# Altium schematic sheet peripherials.SchDoc
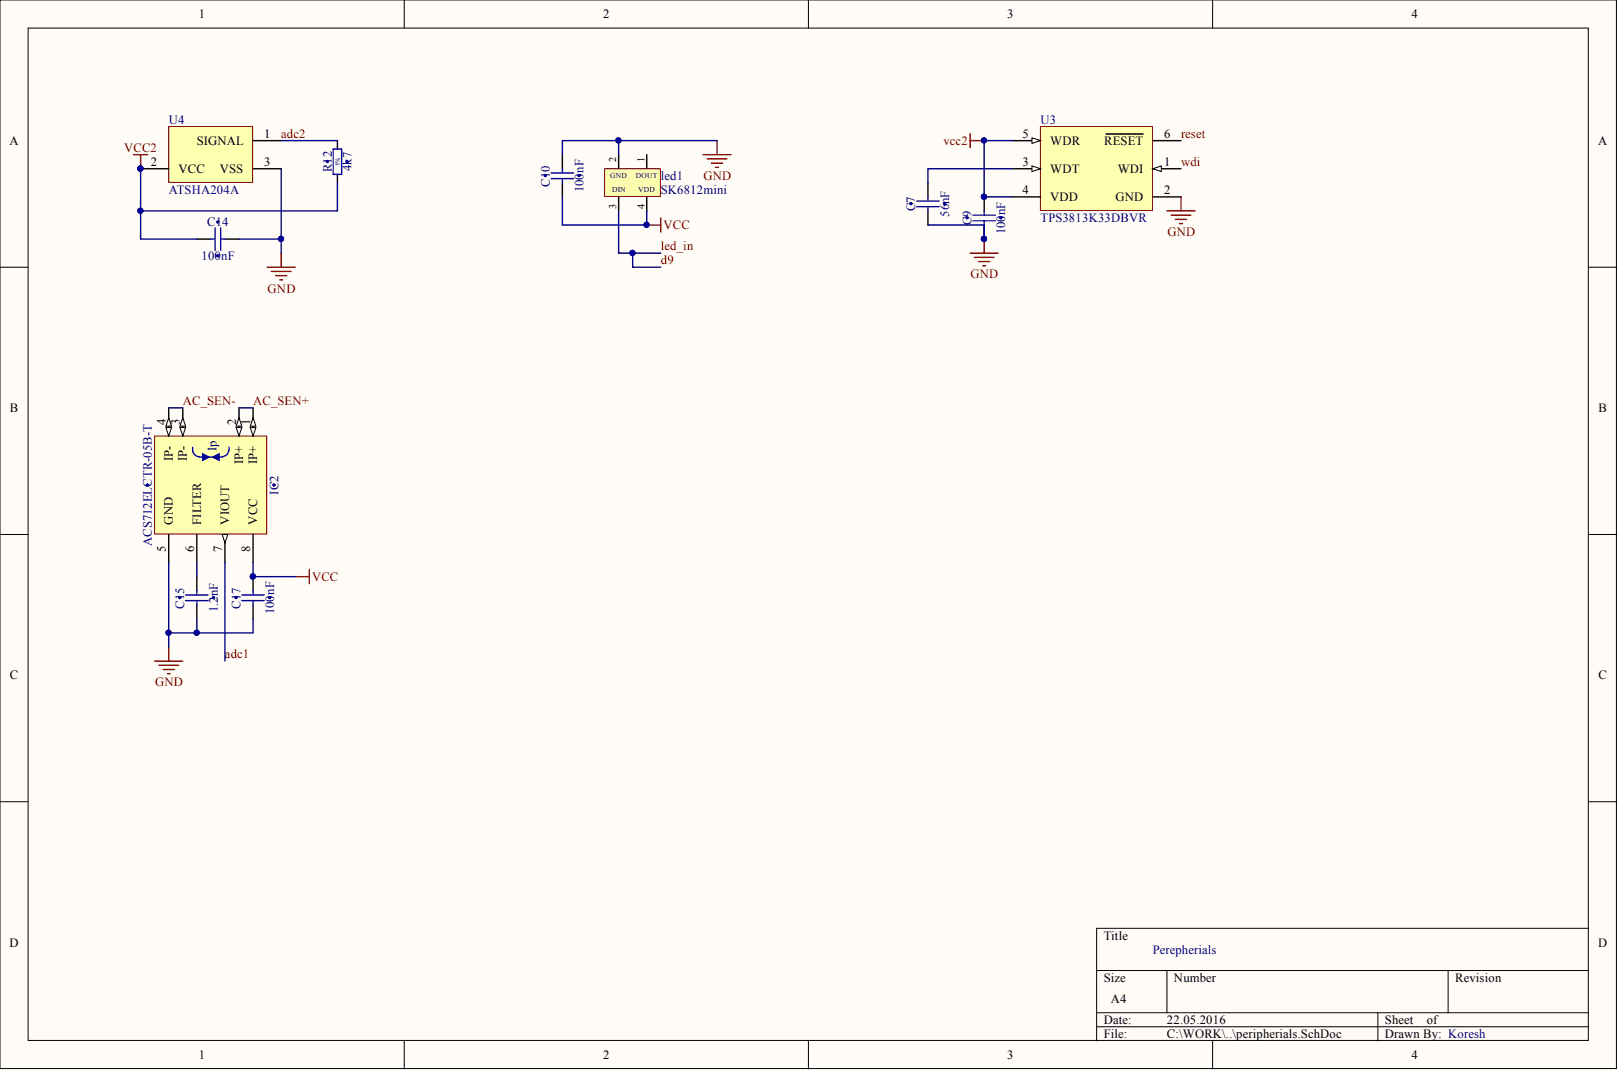
[diagram p0: peripherials.SchDoc]

## Parts
C7  56nF  [CAPC1005X55N]
C9  100nF  [CAPC1005X55N]
C10  100nF  [CAPC1005X55N]
C14  100nF  [CAPC1005X55N]
C15  1.2nF  [CAPC1608X85N]
C17  100nF  [CAPC1608X90N]
IC2  ACS712ELCTR-05B-T  [SOIC127P600X175-8N]
led1  SK6812mini  [SK6812mini]
R12  4k7  [RESC1005X40N]
U3  TPS3813K33DBVR  [DBV6_L]
U4  ATSHA204A  [3TS1_N]

## Nets
GND: C7.1 C9.1 C10.2 C14.2 C15.1 C17.1 IC2.5(GND) led1.2 U3.2(GND) U4.3
VCC: C10.1 C17.2 IC2.8(VCC) led1.4
VCC2: C9.2 C14.1 R12.1 U3.4(VDD) U3.5(WDR) U4.2
AC_SEN+: IC2.1(IP+) IC2.2(IP+)
AC_SEN-: IC2.3(IP-) IC2.4(IP-)
ADC1: IC2.7(VIOUT)
ADC2: R12.2 U4.1
D9: led1.3
RESET: U3.6(RESET)
WDI: U3.1(WDI)
NetC7_2: C7.2 U3.3(WDT)
NetC15_2: C15.2 IC2.6(FILTER)

Not connected: led1 1

## Library data of the parts
C7 = CC0402_68NF_16V_10%_X7R: Non-polarised capacitor; Manufacturer: GENERIC; Manufacturer1 Part Number: 223878715647; Part Description: SMD Multilayer Chip Ceramic Capacitor; Part Number: CC0402_56NF_16V_10%_X7R; Database Table Name: Capacitors SMD; TC: X7R; Tolerance: ±10%; Voltage: 16V; Case: 0402; Component Kind: Standard; Component Type: Standard; CreateDate: 03.12.2007; HelpURL: \\cern.ch\dfs\Applications\Altium\Datasheets\CC0402_X7R_PHYCOMP.pdf; LatestRevisionDate: 03.12.2007; Library Name: Capacitors.DbLib; Manufacturer1 ComponentHeight: 0.55mm; Manufacturer1 Example: PHYCOMP; Manufacturer Part Number: CC0402_68NF_16V_10%_X7R; Mounted: Yes; Pin Count: 2; SMD: Yes; Socket: No; Status: Not Recommended; Table: Capacitors SMD; Value: 56nF; Author: CERN DEM JLC
C9 C10 C14 = CC0402_100NF_16V_10%_X5R: Non-polarised capacitor; Manufacturer: GENERIC; Manufacturer1 Part Number: GRM155R61C104KA88D; Part Description: SMD Multilayer Chip Ceramic Capacitor; Database Table Name: Capacitors SMD; TC: X5R; Tolerance: ±10%; Voltage: 16V; Case: 0402; Component Kind: Standard; Component Type: Standard; CreateDate: 10.12.2013; HelpURL: \\cern.ch\dfs\Applications\Altium\Datasheets\CC0402_X5R_MURATA_GRM.pdf; LatestRevisionDate: 10.12.2013; Library Name: Capacitors.DbLib; Manufacturer1 ComponentHeight: 0.55mm; Manufacturer1 Example: MURATA; Manufacturer Part Number: CC0402_100NF_16V_10%_X5R; Mounted: Yes; Part Number: CC0402_100NF_16V_10%_X5R; Pin Count: 2; SMD: Yes; Socket: No; Status: None; Table: Capacitors SMD; Value: 100nF; Author: CERN DEM JLC
C15 = CPPS0603_1.2NF_16V_5%_PANASONIC_ECHU(X): Non-polarised capacitor; Manufacturer Part Number: ECHU1C122JX5; Part Description: Stacked Metallized PPS (Polyphenylene Sulfide) Film Chip Capacitor; Database Table Name: Capacitors SMD; Tolerance: ±5%; Voltage: 16V; Case: 0603; ComponentHeight: 0.85mm; Component Kind: Standard; Component Type: Standard; CreateDate: 15.06.2011; HelpURL: \\cern.ch\dfs\Applications\Altium\Datasheets\CPPS0603_PANASONIC_ECHU(X).pdf; LatestRevisionDate: 15.06.2011; Library Name: Capacitors.DbLib; Manufacturer: PANASONIC; Mounted: Yes; Part Number: CPPS0603_1.2NF_16V_5%_PANASONIC_ECHU(X); Pin Count: 2; SMD: Yes; Socket: No; Status: None; Table: Capacitors SMD; Value: 1.2nF; Author: CERN DEM JLC
C17 = CC0603_100NF_100V_10%_X7R: Non-polarised capacitor; Manufacturer: GENERIC; Manufacturer1 Part Number: GRM188R72A104KA35D; Part Description: SMD Multilayer Chip Ceramic Capacitor; Database Table Name: Capacitors SMD; TC: X7R; Tolerance: ±10%; Voltage: 100V; Case: 0603; Component Kind: Standard; Component Type: Standard; CreateDate: 11.12.2013; HelpURL: \\cern.ch\dfs\Applications\Altium\Datasheets\CC0603_MURATA_GRM188R72A104KA35D.pdf; LatestRevisionDate: 11.12.2013; Library Name: Capacitors.DbLib; Manufacturer1 ComponentHeight: 0.9mm; Manufacturer1 Example: MURATA; Manufacturer Part Number: CC0603_100NF_100V_10%_X7R; Mounted: Yes; Part Number: CC0603_100NF_100V_10%_X7R; Pin Count: 2; SMD: Yes; Socket: No; Status: None; Table: Capacitors SMD; Value: 100nF; Author: CERN DEM JLC
IC2 = ACS712ELCTR-05B-T: Fully Integrated, Hall Effect-Based Linear Current Sensor IC with 2.1 kVRMS Isolation; Manufacturer: ALLEGRO MICROSYSTEM; Part Description: ±5A 185mV/A Fully Integrated, Hall Effect-Based Linear Current Sensor IC with 2.1 kVRMS Isolation; Database Table Name: Sensors; Case: SOIC8; ComponentHeight: 1.75mm; Component Kind: Standard; Component Type: Standard; CreateDate: 26.05.2014; Device: ACS712ELCTR-05B-T; HelpURL: \\cern.ch\dfs\Applications\Altium\Datasheets\ACS712ELCTR-05B-T.pdf; LatestRevisionDate: 26.05.2014; Library Name: ICs And Semiconductors.DbLib; Manufacturer Part Number: ACS712ELCTR-05B-T; Mounted: Yes; PackageDescription: SOIC 8, Pitch 1.27mm - Body 4x5mm, IPC Medium Density; Part Number: ACS712ELCTR-05B-T; Pin Count: 8; SMD: Yes; Socket: No; Table: Sensors; Author: CERN DEM JLC
R12 = R0402_4K7_1%_0.0625W_100PPM: Resistor - 1%; Manufacturer: GENERIC; Manufacturer1 Part Number: 232270674702L; Part Description: General Purpose Thick Film Chip Resistor; Database Table Name: Resistors SMD; TC: ±100ppm/°C; Case: 0402; Component Kind: Standard; Component Type: Standard; CreateDate: 03.12.2007; HelpURL: \\cern.ch\dfs\Applications\Altium\Datasheets\R0402_Phycomp_RC0402.pdf; LatestRevisionDate: 17.10.2012; Library Name: Resistors.DbLib; Manufacturer1 ComponentHeight: 0.4mm; Manufacturer1 Example: YAGEO PHYCOMP; Manufacturer Part Number: R0402_4K7_1%_0.0625W_100PPM; Mounted: Yes; Part Number: R0402_4K7_1%_0.0625W_100PPM; Pin Count: 2; Power: 0.0625W; SMD: Yes; Socket: No; Status: Not recommended; Table: Resistors SMD; Tolerance: ±1%; Value: 4k7; Author: CERN DEM JLC
U3 = CMP-0332-00489-1: Supervisor with Programmable Watchdog Window, 1 Supply Monitored, -40 to 85 degC, 6-pin SOT-23 (DBV), Green (RoHS & no Sb/Br); Manufacturer: Texas Instruments; # of supplies monitored: 1; Iq(Typ)(uA): 9; Output Driver Type / Reset Output: Active-low, Open-drain; Rating: Catalog; Reset Threshold Accuracy(%): 2.2; SubFamily: Supervisor and Reset IC; Threshold Voltage 1 (typ)(Typ)(V): 2.93; Time Delay(ms): 25; VCC(Max)(V): 6; VCC(Min)(V): 2; Code_JEDEC: MO-178-AB*; Component Kind: Standard; ComponentLink1Description: Datasheet; ComponentLink1URL: http://www.ti.com/general/docs/lit/getliterature.tsp?genericPartNumber=TPS3813K33&fileType=pdf; DatasheetVersion: SLVS331F; Library Name: Altium Content Vault; Mounting Technology: Surface Mount; PackageDescription: 6-Pin Plastic Small-Outline Package, 2.9 x 1.6 mm Body, 0.95 mm Pitch, 2.8 mm Lead Span; PackageReference: DBV6; PackageVersion: revK, Mar-2006; PartNumber: TPS3813K33DBVR; Watchdog Timer WDI(sec): Programmable
U4 = AT88SA10HS-TSU-T: CryptoAuthentication™ Host Security Chip, Industrial (-40 to 85 degC), 3-Pin SOT, Tape and Reel, Pb-Free; Code_IPC: SOT23-3; Code_JEDEC: TO-236-AB; Component Kind: Standard; ComponentLink1Description: Manufacturer URL; ComponentLink1URL: http://www.atmel.com/; ComponentLink2Description: Datasheet; ComponentLink2URL: http://www.atmel.com/dyn/resources/prod_documents/doc8595.pdf; DatasheetVersion: revD, May-2010; Library Name: Atmel Security Smart Card.IntLib; PackageDescription: 3-Pin Plastic Thin Shrink Small Outline Package, 2.9 x 1.3 mm Body, 0.95 mm Pitch (Shrink SOT); PackageReference: 3TS1; PackageVersion: revA,Nov-2008
led1 = SK6812mini: Component Kind: Standard; Library Name: SK6812.SchLib
